# Altium schematic sheet Microcontrolador.SchDoc(Microcontrolador)
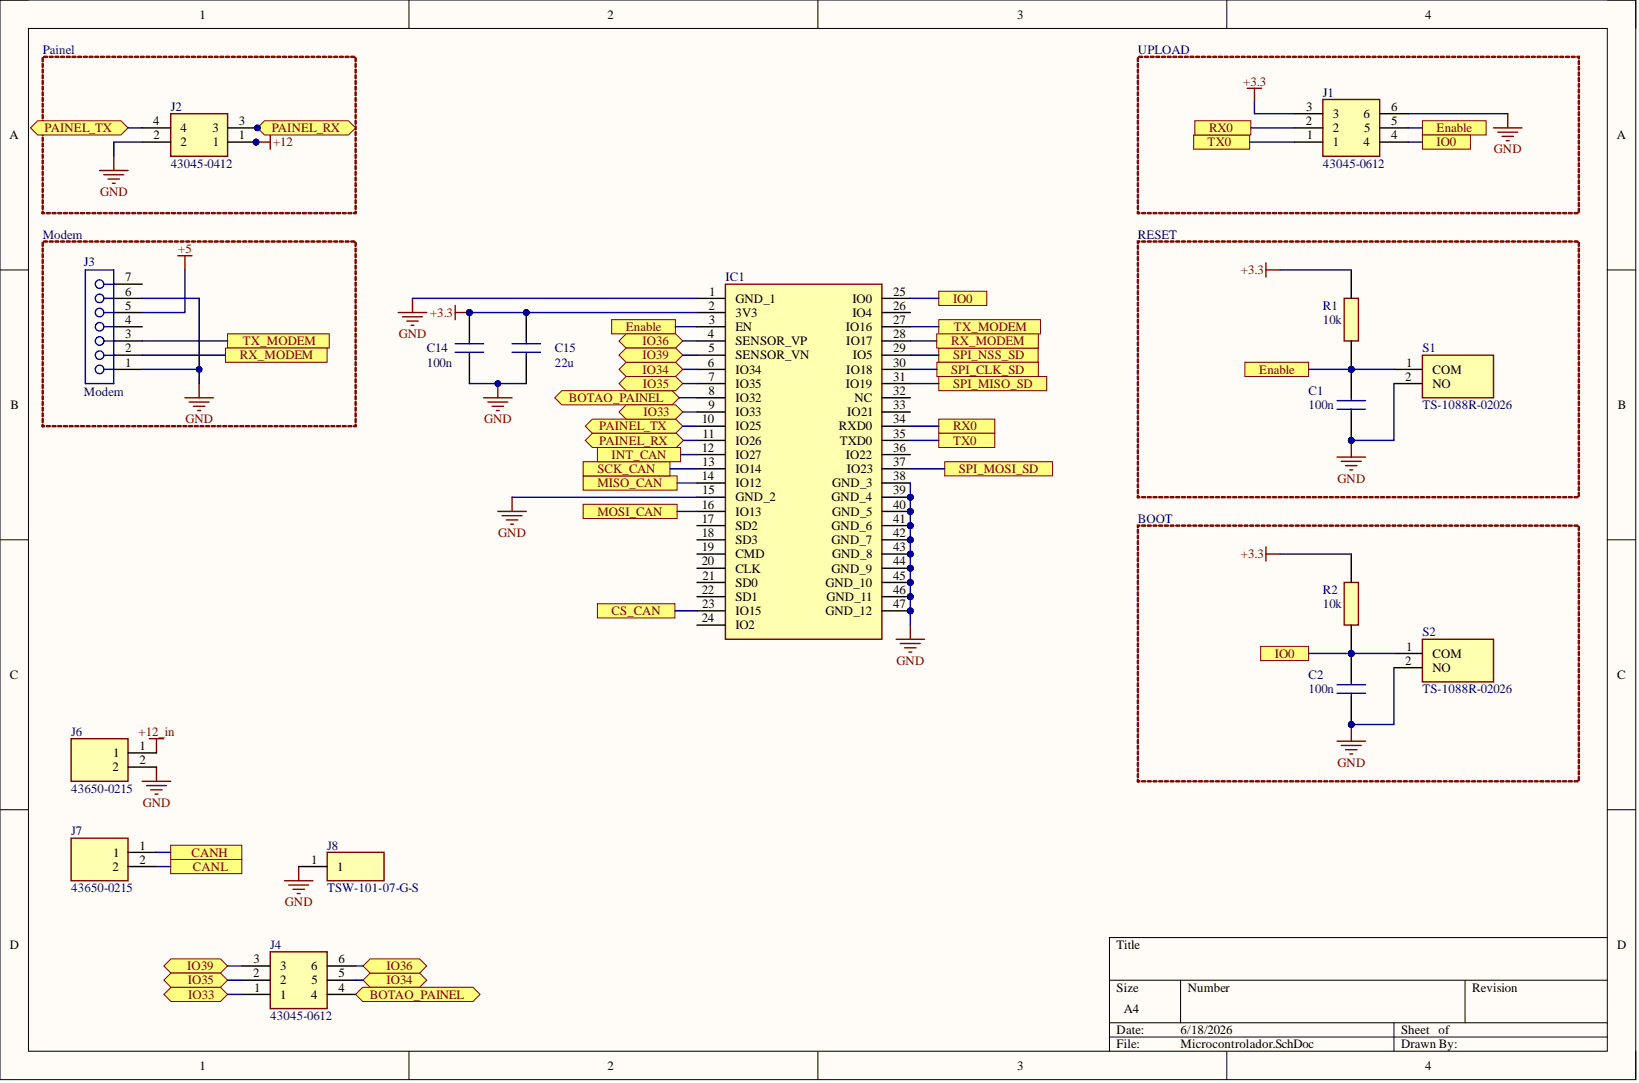
[diagram p0: Microcontrolador.SchDoc]

## Parts
C1  100n
C2  100n
C14  22u
C15  100n
IC1  ESP32-WROOM-32_M103QH2800PH3Q0_  (value not drawn)
J1  43045-0612
J2  43045-0412
J3  Modem
J4  43045-0612
J6  43650-0215
J7  43650-0215
J8  TSW-101-07-G-S
R1  10k
R2  10k
S1  TS-1088R-02026
S2  TS-1088R-02026

## Ports
BOTAO_PAINEL, CANH, CANL, CS_CAN, Enable, INT_CAN, IO0, IO33, IO34, IO35, IO36, IO39, MISO_CAN, MOSI_CAN, PAINEL_RX, PAINEL_TX, RX0, RX_MODEM, SCK_CAN, SPI_CLK_SD, SPI_MISO_SD, SPI_MOSI_SD, SPI_NSS_SD, TX0, TX_MODEM

## Nets
+3.3: C14.2 C15.1 IC1.2(3V3) J1.3 R1.1 R2.1
+5: J3.5
+12: J2.1
+12_in: J6.1
GND: C1.1 C2.1 C14.1 C15.2 IC1.1(GND_1) IC1.15(GND_2) IC1.38(GND_3) IC1.39(GND_4) IC1.40(GND_5) IC1.41(GND_6) IC1.42(GND_7) IC1.43(GND_8) IC1.44(GND_9) IC1.45(GND_10) IC1.46(GND_11) IC1.47(GND_12) J1.6 J2.2 J3.1 J3.6 J6.2 J8.1 S1.2 S2.2
BOTAO_PAINEL: IC1.8(IO32) J4.4 ; port BOTAO_PAINEL
CANH: J7.1 ; port CANH
CANL: J7.2 ; port CANL
CS_CAN: IC1.23(IO15) ; port CS_CAN
Enable: C1.2 IC1.3(EN) J1.5 R1.2 S1.1 ; port Enable
INT_CAN: IC1.12(IO27) ; port INT_CAN
IO0: C2.2 IC1.25(IO0) J1.4 R2.2 S2.1 ; port IO0
IO33: IC1.9(IO33) J4.1 ; port IO33
IO34: IC1.6(IO34) J4.5 ; port IO34
IO35: IC1.7(IO35) J4.2 ; port IO35
IO36: IC1.4(SENSOR_VP) J4.6 ; port IO36
IO39: IC1.5(SENSOR_VN) J4.3 ; port IO39
MISO_CAN: IC1.14(IO12) ; port MISO_CAN
MOSI_CAN: IC1.16(IO13) ; port MOSI_CAN
PAINEL_RX: IC1.11(IO26) J2.3 ; port PAINEL_RX
PAINEL_TX: IC1.10(IO25) J2.4 ; port PAINEL_TX
RX0: IC1.34(RXD0) J1.2 ; port RX0
RX_MODEM: IC1.28(IO17) J3.2 ; port RX_MODEM
SCK_CAN: IC1.13(IO14) ; port SCK_CAN
SPI_CLK_SD: IC1.30(IO18) ; port SPI_CLK_SD
SPI_MISO_SD: IC1.31(IO19) ; port SPI_MISO_SD
SPI_MOSI_SD: IC1.37(IO23) ; port SPI_MOSI_SD
SPI_NSS_SD: IC1.29(IO5) ; port SPI_NSS_SD
TX0: IC1.35(TXD0) J1.1 ; port TX0
TX_MODEM: IC1.27(IO16) J3.3 ; port TX_MODEM

Not connected: IC1 17(SD2) 18(SD3) 19(CMD) 20(CLK) 21(SD0) 22(SD1) 24(IO2) 26(IO4) 32(NC) 33(IO21) 36(IO22); J3 4 7

## Library data of the parts
C1 C2 C15 = CL21B104KBCNNNC: Capacitor; Manufacturer_Name: SAMSUNG; Arrow Part Number: CL21B104KBCNNNC; Arrow Price/Stock: https://www.arrow.com/en/products/cl21b104kbcnnnc/samsung-electro-mechanics?region=europe; Component Kind: Standard; Datasheet Link: https://datasheet.datasheetarchive.com/originals/distributors/Datasheets_SAMA/86a3eaf0882763ef32124d5901f9b833.pdf; Height: 0.95mm; Manufacturer_Part_Number: CL21B104KBCNNNC; Mouser Part Number: 187-CL21B104KBCNNNC; Mouser Price/Stock: https://www.mouser.co.uk/ProductDetail/Samsung-Electro-Mechanics/CL21B104KBCNNNC?qs=349EhDEZ59pEfpnm5yBEmQ%3D%3D
C14 = CL21A226MAYNNNE: Capacitor; Manufacturer_Name: SAMSUNG; Arrow Part Number: CL21A226MAYNNNE; Arrow Price/Stock: https://www.arrow.com/en/products/cl21a226maynnne/samsung-electro-mechanics?utm_currency=USD&region=europe; Component Kind: Standard; Datasheet Link: http://product.samsungsem.com/mlcc/CL21A226MAYNNN.do; Height: 1.45mm; Manufacturer_Part_Number: CL21A226MAYNNNE; Mouser Part Number: 187-CL21A226MAYNNNE; Mouser Price/Stock: https://www.mouser.co.uk/ProductDetail/Samsung-Electro-Mechanics/CL21A226MAYNNNE?qs=xZ%2FP%252Ba9zWqY%2FVvCfXjMhqg%3D%3D
IC1 = ESP32-WROOM-32_M103QH2800PH3Q0_: Integrated Circuit; Manufacturer_Name: Espressif Systems; Manufacturer_Part_Number: ESP32-WROOM-32(M103QH2800PH3Q0); Component Kind: Standard; Datasheet Link: https://th.mouser.com/datasheet/2/891/esp32-wroom-32_datasheet_en-1510934.pdf; Height: 3.2mm; Library Name: SamacSys.SchLib; Mouser Part Number: 356-ESPWROOM3216MB; Mouser Price/Stock: https://www.mouser.co.uk/ProductDetail/Espressif-Systems/ESP32-WROOM-32M103QH2800PH3Q0?qs=W%2FMpXkg%252BdQ7IcgHFTTq3Ig%3D%3D
J1 J4 = 43045-0612: Connector; Manufacturer_Name: Molex; Arrow Part Number: 43045-0612; Arrow Price/Stock: https://www.arrow.com/en/products/43045-0612/molex?region=nac; Component Kind: Standard; Datasheet Link: https://www.molex.com/pdm_docs/sd/430450612_sd.pdf; Height: 9.91mm; Library Name: SamacSys.SchLib; Manufacturer_Part_Number: 43045-0612; Mouser Part Number: 538-43045-0612; Mouser Price/Stock: https://www.mouser.co.uk/ProductDetail/Molex/43045-0612?qs=KC2ywxza1kqWo9LLJ5Lh3w%3D%3D
J2 = 43045-0412: Connector; Manufacturer_Name: Molex; Arrow Part Number: 43045-0412; Arrow Price/Stock: http://www.arrow.com/en/products/43045-0412/molex; Component Kind: Standard; Datasheet Link: https://www.molex.com/pdm_docs/sd/430450612_sd.pdf; Height: 9.91mm; Library Name: SamacSys.SchLib; Manufacturer_Part_Number: 43045-0412; Mouser Part Number: 538-43045-0412; Mouser Price/Stock: https://www.mouser.co.uk/ProductDetail/Molex/43045-0412?qs=UeCeOHRHQebPBbEMDLocEw%3D%3D
J3 = CMP-1023-00138-1: Low Profile Socket Strips, Through-hole, Vertical, -55 to 125 degC, 2.54 mm Pitch, 7-Pin, Female, RoHS; Manufacturer: Samtec; PartNumber: SLW-107-01-G-S; Pitch: 2.54 mm; Component Kind: Standard; ComponentLink1Description: Manufacturer URL; ComponentLink1URL: http://www.samtec.com; ComponentLink2Description: Datasheet; ComponentLink2URL: http://www.samtec.com/documents/webfiles/pdf/SLW.PDF; ComponentLink3Description: Package Specification; ComponentLink3URL: http://www.samtec.com/documents/webfiles/cpdf/SLW-1XX-01-X-X-MKT.pdf; DatasheetVersion: F-214; Library Name: Altium Content Vault; Mounting Technology: Through-hole; Orientation: Vertical; PackageDescription: Low Profile Socket Strip; PackageReference: SLW-107-01-X-S; PackageVersion: X, 9/1987; RoHS: True; Temperature Range: -55 to 125 degC
J6 J7 = 43650-0215: Connector; Manufacturer_Name: Molex; Component Kind: Standard; Datasheet Link: https://www.molex.com/pdm_docs/sd/436500215_sd.pdf; Height: 9.9mm; Library Name: SamacSys.SchLib; Manufacturer_Part_Number: 43650-0215; Mouser Part Number: 538-43650-0215; Mouser Price/Stock: https://www.mouser.co.uk/ProductDetail/Molex/43650-0215?qs=AplfTeSvkkDe0NAwMnlPNw%3D%3D
J8 = TSW-101-07-G-S: Connector; Manufacturer_Name: SAMTEC; Arrow Part Number: TSW-101-07-G-S; Arrow Price/Stock: https://www.arrow.com/en/products/tsw-101-07-g-s/samtec?region=europe; Component Kind: Standard; Datasheet Link: http://suddendocs.samtec.com/prints/tsw-xxx-xx-xxx-x-xx-xxx-mkt.pdf; Manufacturer_Part_Number: TSW-101-07-G-S; Mouser Part Number: 200-TSW10107GS; Mouser Price/Stock: https://www.mouser.co.uk/ProductDetail/Samtec/TSW-101-07-G-S?qs=hzq9O0YpnZscfPe5oPvABA%3D%3D
R1 R2 = RC0805FR-0710KL: Resistor; Manufacturer_Name: YAGEO; Arrow Part Number: RC0805FR-0710KL; Arrow Price/Stock: https://www.arrow.com/en/products/rc0805fr-0710kl/yageo?utm_currency=USD&region=europe; Component Kind: Standard; Datasheet Link: https://www.yageo.com/upload/media/product/productsearch/datasheet/rchip/PYu-RC_Group_51_RoHS_L_11.pdf; Height: 0.6mm; Manufacturer_Part_Number: RC0805FR-0710KL; Mouser Part Number: 603-RC0805FR-0710KL; Mouser Price/Stock: https://www.mouser.co.uk/ProductDetail/YAGEO/RC0805FR-0710KL?qs=7bY8808IzHq6vrU3BeiiYw%3D%3D
S1 S2 = TS-1088R-02026: Switch; Manufacturer_Name: XUNPU; Component Kind: Standard; Datasheet Link: https://datasheet.lcsc.com/szlcsc/1912111437_XUNPU-TS-1088R-02026_C455280.pdf; Manufacturer_Part_Number: TS-1088R-02026
